# Altium schematic sheet board_sheet2.SchDoc
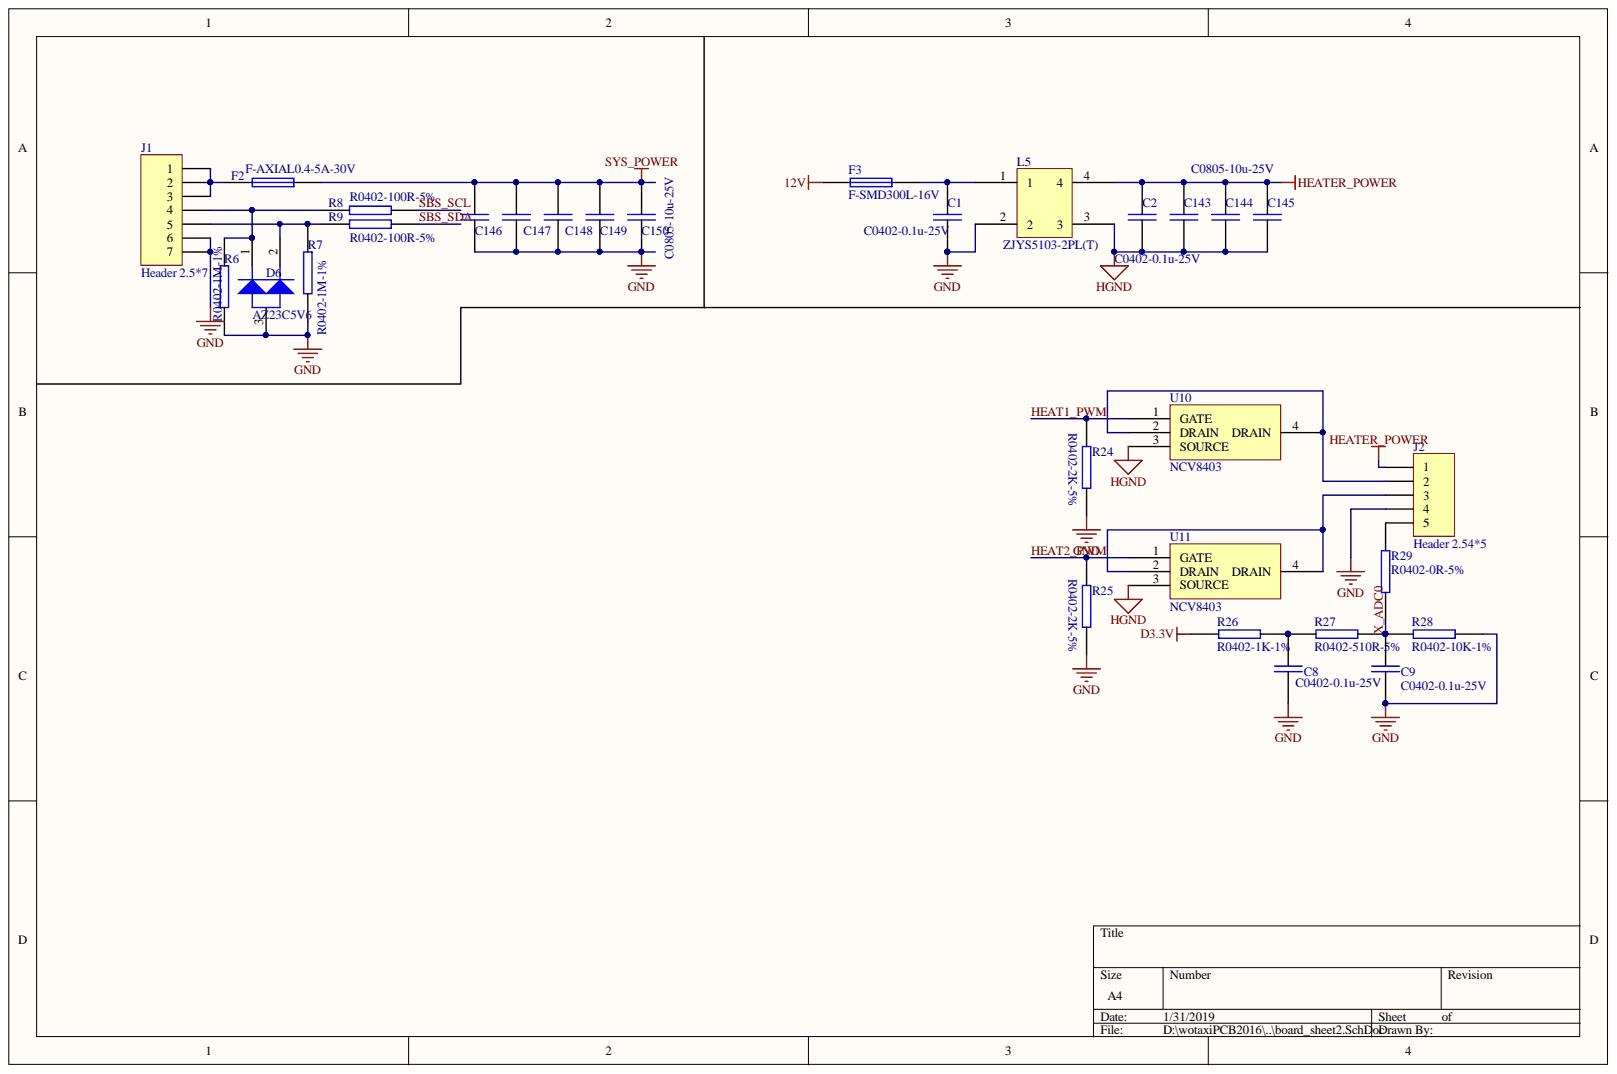
[diagram p0: board_sheet2.SchDoc]

## Parts
C1  C0402-0.1u-25V  [0402]
C2  C0402-0.1u-25V  [0402]
C8  C0402-0.1u-25V  [0402]
C9  C0402-0.1u-25V  [0402]
C143  (no value)  [0805]
C144  (no value)  [0805]
C145  C0805-10u-25V  [0805]
C146  (no value)  [0805]
C147  (no value)  [0805]
C148  (no value)  [0805]
C149  (no value)  [0805]
C150  C0805-10u-25V  [0805]
D6  AZ23C5V6  [SO-G3/C2.5]
F2  F-AXIAL0.4-5A-30V  [C3216-1206]
F3  F-SMD300L-16V  [C5750-2220]
J1  Header 2.5*7  [DDB02MC-N00S6-02NB-2U]
J2  Header 2.54*5  [CH2.54*5]
L5  ZJYS5103-2PL(T)  [ZJYS5103]
R6  R0402-1M-1%  [0402]
R7  R0402-1M-1%  [0402]
R8  R0402-100R-5%  [0402]
R9  R0402-100R-5%  [0402]
R24  R0402-2K-5%  [0402]
R25  R0402-2K-5%  [0402]
R26  R0402-1K-1%  [0402]
R27  R0402-510R-5%  [0402]
R28  R0402-10K-1%  [0402]
R29  R0402-0R-5%  [0402]
U10  NCV8403  [DSO-G3]
U11  NCV8403  [DSO-G3]

## Nets
12V: F3.1
D3.3V: R26.1
GND: C1.2 C8.2 C9.2 C146.2 C147.2 C148.2 C149.2 C150.2 D6.3 J1.6 J1.7 J2.4 L5.2 R6.2 R7.1 R24.1 R25.1 R28.2
HEATER_POWER: C2.1 C143.1 C144.1 C145.1 J2.1 L5.4
HGND: C2.2 C143.2 C144.2 C145.2 L5.3 U10.3(SOURCE) U11.3(SOURCE)
SYS_POWER: C146.1 C147.1 C148.1 C149.1 C150.1 F2.2
HEAT1_PWM: R24.2 U10.1(GATE)
HEAT2_PWM: R25.2 U11.1(GATE)
SBS_SCL: R8.2
SBS_SDA: R9.2
X_ADC0: C9.1 R27.2 R28.1 R29.1
NetC1_1: C1.1 F3.2 L5.1
NetC8_1: C8.1 R26.2 R27.1
NetD6_1: D6.1 J1.4 R6.1 R8.1
NetD6_2: D6.2 J1.5 R7.2 R9.1
NetF2_1: F2.1 J1.1 J1.2 J1.3
NetJ2_2: J2.2 U10.2(DRAIN) U10.4(DRAIN)
NetJ2_3: J2.3 U11.2(DRAIN) U11.4(DRAIN)
NetJ2_5: J2.5 R29.2

## Library data of the parts
C1 C2 C8 C9 C143-C150 = CAP: Component Kind: Standard; Library Name: KL300-POWER.SCHLIB
D6 = BAT54ALT1: Component Kind: Standard; Library Name: KL300-POWER.SCHLIB
F2 F3 = FUSE: Component Kind: Standard; Library Name: KL300-POWER.SCHLIB
J1 = MHDR1X7: Component Kind: Standard; Library Name: KL300-POWER.SCHLIB
J2 = MHDR1X5: Component Kind: Standard; Library Name: KL300-POWER.SCHLIB
L5 = ZJYS5103-2PL: Component Kind: Standard; Library Name: KL300-POWER.SCHLIB
R6-R9 R24-R29 = RES: Component Kind: Standard; Library Name: KL300-POWER.SCHLIB
U10 U11 = NCV8403: Component Kind: Standard; Library Name: KL300-POWER.SCHLIB
